# Altium schematic sheet lpGBT.SchDoc
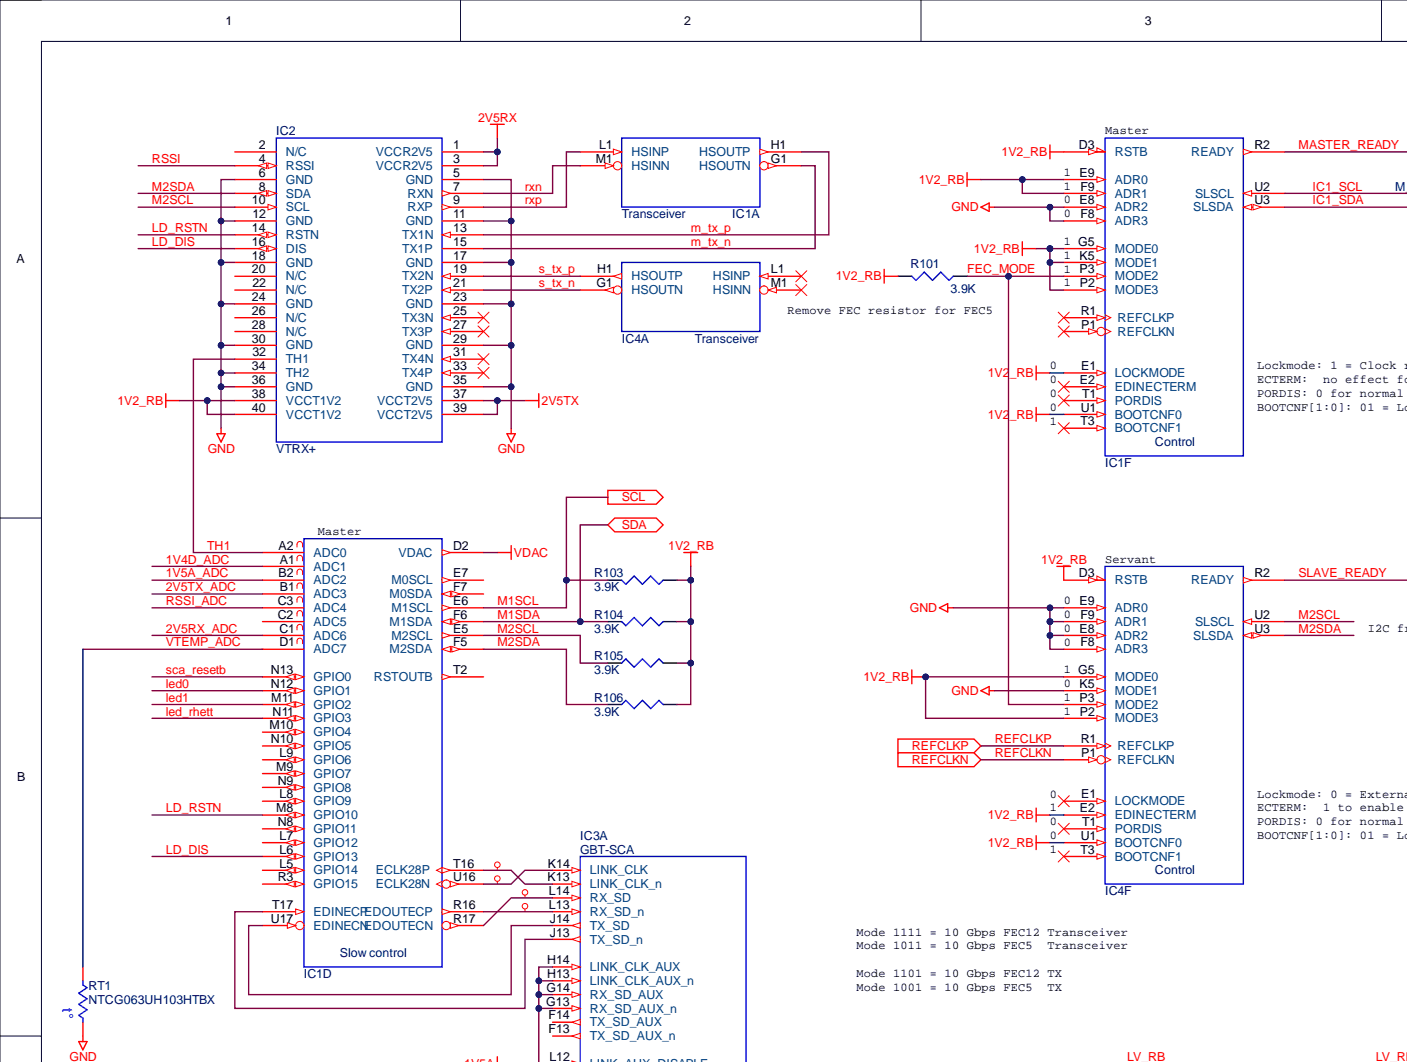
[diagram p0: lpGBT.SchDoc, top left]
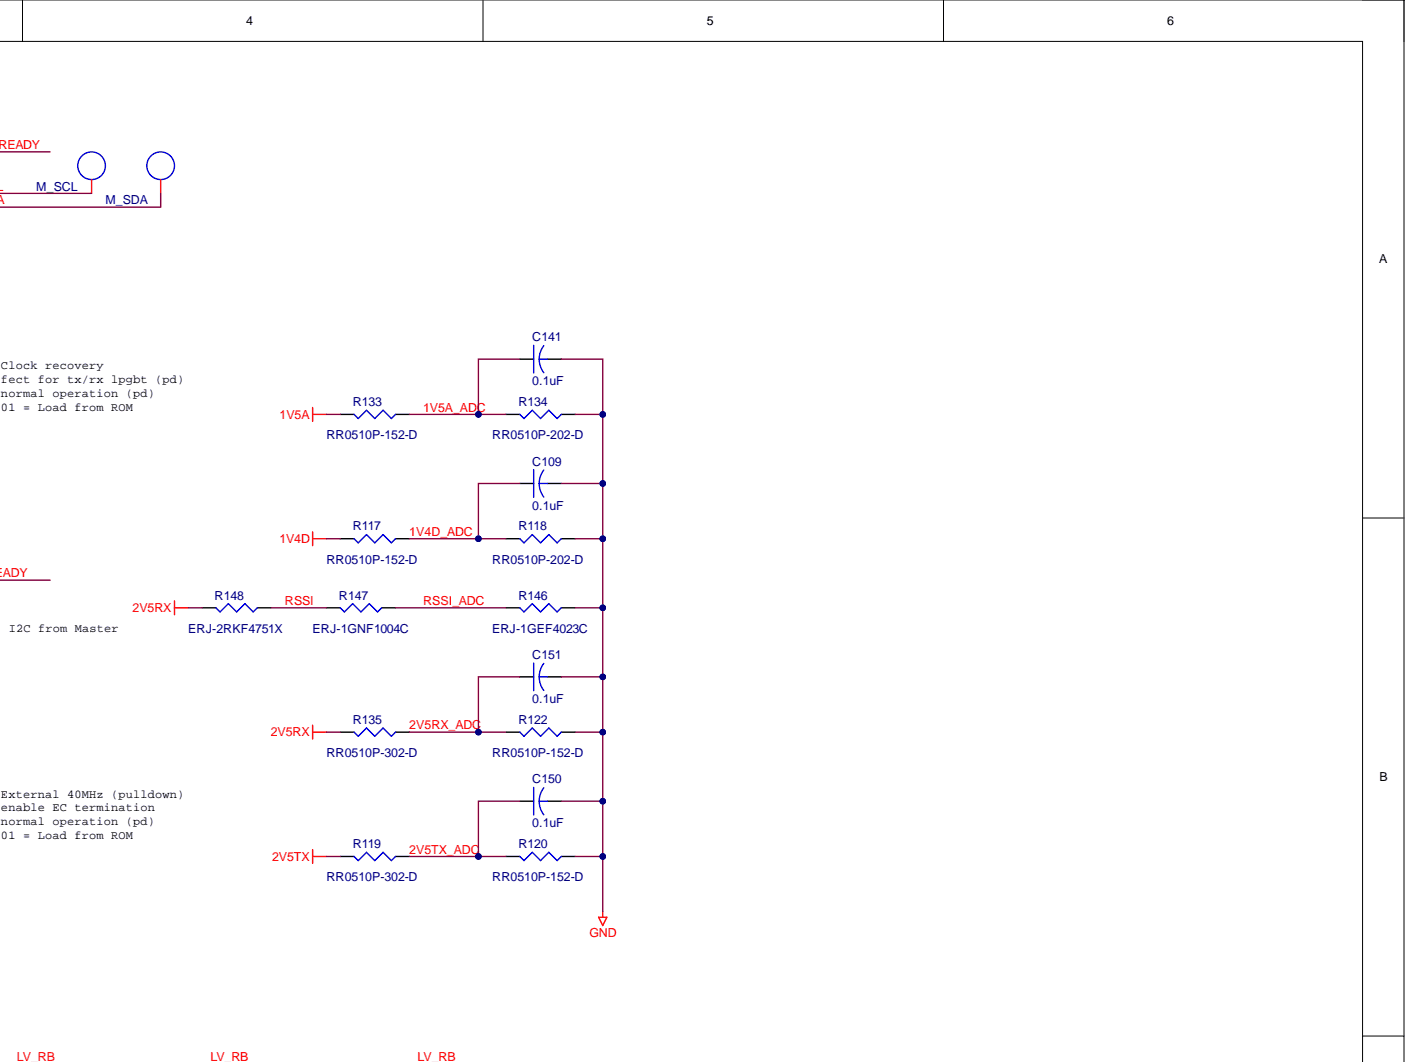
[diagram p1: lpGBT.SchDoc, top right]
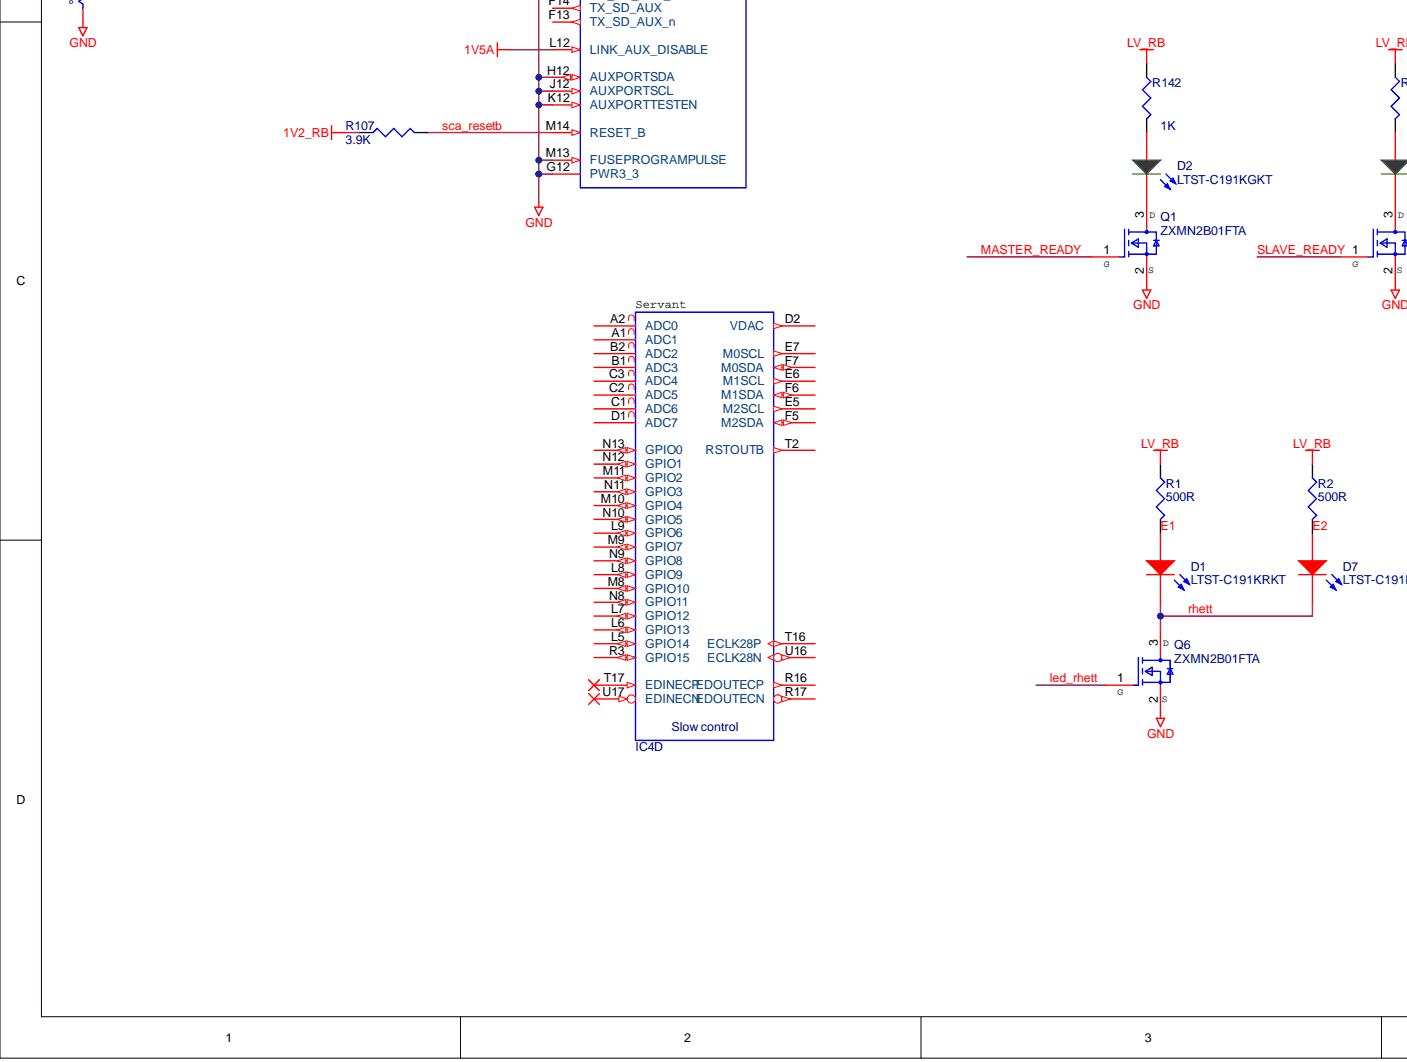
[diagram p2: lpGBT.SchDoc, bottom left]
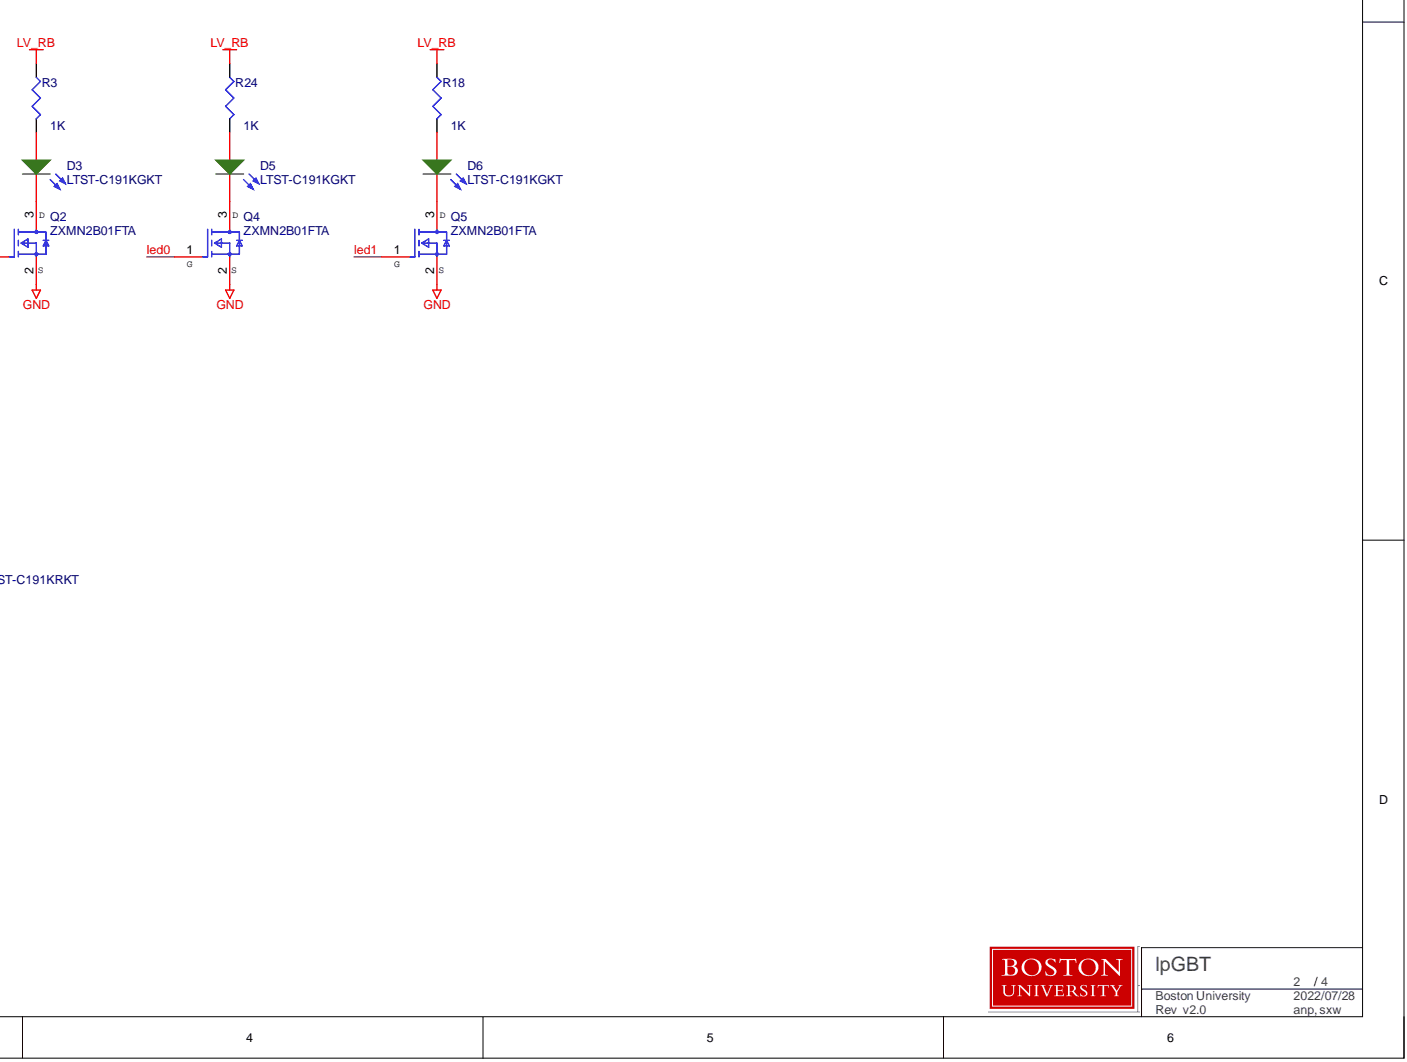
[diagram p3: lpGBT.SchDoc, bottom right]

## Parts
C109  value 0.1uF  [capc1005n]  (hidden comment: GRM155R71E104ME14D)
C141  value 0.1uF  [capc1005n]  (hidden comment: GRM155R71E104ME14D)
C150  value 0.1uF  [capc1005n]  (hidden comment: GRM155R71E104ME14D)
C151  value 0.1uF  [capc1005n]  (hidden comment: GRM155R71E104ME14D)
D1  LTST-C191KRKT  [LED_RED]
D2  LTST-C191KGKT  [LED_RED]
D3  LTST-C191KGKT  [LED_RED]
D5  LTST-C191KGKT  [LED_RED]
D6  LTST-C191KGKT  [LED_RED]
D7  LTST-C191KRKT  [LED_RED]
IC1  LPGBT  [BGA289C50P17X17_900X900X128]  (value not drawn)  (parts A,D,F)
IC2  DF40C-40DS-0.4V  [vtrx+]  (value not drawn)
IC3  GBT-SCA  [BGA196C80P14X14_1200X1200X170]  (part A)
IC4  LPGBT  [BGA289C50P17X17_900X900X128]  (value not drawn)  (parts A,D,F)
Q1  ZXMN2B01FTA  [SOT-23]
Q2  ZXMN2B01FTA  [SOT-23]
Q4  ZXMN2B01FTA  [SOT-23]
Q5  ZXMN2B01FTA  [SOT-23]
Q6  ZXMN2B01FTA  [SOT-23]
R1  Parameter 1: 500R  [resc1005n]  (hidden comment: ERJ-2GEJ102X)
R2  Parameter 1: 500R  [resc1005n]  (hidden comment: ERJ-2GEJ102X)
R3  ERJ-2GEJ102X  [resc1005n]  (value not drawn)
R18  ERJ-2GEJ102X  [resc1005n]  (value not drawn)
R24  ERJ-2GEJ102X  [resc1005n]  (value not drawn)
R101  Parameter 1: 3.9K  [resc1005n]  (hidden comment: ERJ-2GEJ392X)
R103  Parameter 1: 3.9K  [resc1005n]  (hidden comment: ERJ-2GEJ392X)
R104  Parameter 1: 3.9K  [resc1005n]  (hidden comment: ERJ-2GEJ392X)
R105  Parameter 1: 3.9K  [resc1005n]  (hidden comment: ERJ-2GEJ392X)
R106  Parameter 1: 3.9K  [resc1005n]  (hidden comment: ERJ-2GEJ392X)
R107  Parameter 1: 3.9K  [resc1005n]  (hidden comment: ERJ-2GEJ392X)
R117  RR0510P-152-D  [resc1005n]
R118  RR0510P-202-D  [resc1005n]
R119  RR0510P-302-D  [resc1005n]
R120  RR0510P-152-D  [resc1005n]
R122  RR0510P-152-D  [resc1005n]
R133  RR0510P-152-D  [resc1005n]
R134  RR0510P-202-D  [resc1005n]
R135  RR0510P-302-D  [resc1005n]
R142  ERJ-2GEJ102X  [resc1005n]  (value not drawn)
R146  ERJ-1GEF4023C  [resc0603]
R147  ERJ-1GNF1004C  [resc0603]
R148  ERJ-2RKF4751X  [resc1005n]
RT1  NTCG063UH103HTBX  [resc0603]
TP11  M_SCL  [testpoint]  (no BOM)
TP12  M_SDA  [testpoint]  (no BOM)

## Ports
REFCLKN, REFCLKP, SCL, SDA

## Nets
1V2_RB: IC1.D3(RSTB) IC1.E1(LOCKMODE) IC1.E9(ADR0) IC1.F9(ADR1) IC1.G5(MODE0) IC1.K5(MODE1) IC1.P2(MODE3) IC1.U1(BOOTCNF0) IC2.38(VCCT1V2) IC2.40(VCCT1V2) IC4.D3(RSTB) IC4.E2(EDINECTERM) IC4.G5(MODE0) IC4.P2(MODE3) IC4.U1(BOOTCNF0) R101.2 R103.1 R104.1 R105.2 R106.2 R107.1
1V4D: R117.2
1V5A: IC3.L12(LINK_AUX_DISABLE) R133.2
2V5RX: IC2.1(VCCR2V5) IC2.3(VCCR2V5) R135.2 R148.2
2V5TX: IC2.37(VCCT2V5) IC2.39(VCCT2V5) R119.2
GND: C109.2 C141.2 C150.2 C151.2 IC1.E8(ADR2) IC1.F8(ADR3) IC2.5(GND) IC2.6(GND) IC2.11(GND) IC2.12(GND) IC2.17(GND) IC2.18(GND) IC2.23(GND) IC2.24(GND) IC2.29(GND) IC2.30(GND) IC2.34(TH2) IC2.35(GND) IC2.36(GND) IC3.G12(PWR3_3) IC3.G13(RX_SD_AUX_n) IC3.G14(RX_SD_AUX) IC3.H12(AUXPORTSDA) IC3.H13(LINK_CLK_AUX_n) IC3.H14(LINK_CLK_AUX) IC3.J12(AUXPORTSCL) IC3.K12(AUXPORTTESTEN) IC3.M13(FUSEPROGRAMPULSE) IC4.E8(ADR2) IC4.E9(ADR0) IC4.F8(ADR3) IC4.F9(ADR1) IC4.K5(MODE1) Q1.2 Q2.2 Q4.2 Q5.2 Q6.2 R118.1 R120.1 R122.1 R134.1 R146.1 RT1.1
LV_RB: R1.1 R2.1 R3.1 R18.1 R24.1 R142.1
VDAC: IC1.D2(VDAC)
1V4D_ADC: C109.1 IC1.A1(ADC1) R117.1 R118.2
1V5A_ADC: C141.1 IC1.B2(ADC2) R133.1 R134.2
2V5RX_ADC: C151.1 IC1.C1(ADC6) R122.2 R135.1
2V5TX_ADC: C150.1 IC1.B1(ADC3) R119.1 R120.2
E1: D1.1 R1.2
E2: D7.1 R2.2
FEC_MODE: IC1.P3(MODE2) IC4.P3(MODE2) R101.1
IC1_SCL: IC1.U2(SLSCL) TP11.1
IC1_SDA: IC1.U3(SLSDA) TP12.1
LD_DIS: IC1.L6(GPIO13) IC2.16(DIS)
LD_RSTN: IC1.M8(GPIO10) IC2.14(RSTN)
led0: IC1.N12(GPIO1) Q4.1
led1: IC1.M11(GPIO2) Q5.1
led_rhett: IC1.N11(GPIO3) Q6.1
M1SCL: IC1.E6(M1SCL) R103.2 ; port SCL
M1SDA: IC1.F6(M1SDA) R104.2 ; port SDA
M2SCL: IC1.E5(M2SCL) IC2.10(SCL) IC4.U2(SLSCL) R105.1
M2SDA: IC1.F5(M2SDA) IC2.8(SDA) IC4.U3(SLSDA) R106.1
m_tx_n: IC1.G1(HSOUTN) IC2.15(TX1P)
m_tx_p: IC1.H1(HSOUTP) IC2.13(TX1N)
MASTER_READY: IC1.R2(READY) Q1.1
REFCLKN: IC4.P1(REFCLKN) ; port REFCLKN
REFCLKP: IC4.R1(REFCLKP) ; port REFCLKP
rhett: D1.2 D7.2 Q6.3
RSSI: IC2.4(RSSI) R147.2 R148.1
RSSI_ADC: IC1.C3(ADC4) R146.2 R147.1
rxn: IC1.M1(HSINN) IC2.7(RXN)
rxp: IC1.L1(HSINP) IC2.9(RXP)
s_tx_n: IC2.21(TX2P) IC4.G1(HSOUTN)
s_tx_p: IC2.19(TX2N) IC4.H1(HSOUTP)
sca_resetb: IC1.N13(GPIO0) IC3.M14(RESET_B) R107.2
SLAVE_READY: IC4.R2(READY) Q2.1
TH1: IC1.A2(ADC0) IC2.32(TH1)
VTEMP_ADC: IC1.D1(ADC7) RT1.2
NetD2_1: D2.1 R142.2
NetD2_2: D2.2 Q1.3
NetD3_1: D3.1 R3.2
NetD3_2: D3.2 Q2.3
NetD5_1: D5.1 R24.2
NetD5_2: D5.2 Q4.3
NetD6_1: D6.1 R18.2
NetD6_2: D6.2 Q5.3
NetIC1_R16: IC1.R16(EDOUTECP) IC3.L13(RX_SD_n)
NetIC1_R17: IC1.R17(EDOUTECN) IC3.L14(RX_SD)
NetIC1_T16: IC1.T16(ECLK28P) IC3.K13(LINK_CLK_n)
NetIC1_T17: IC1.T17(EDINECP) IC3.J13(TX_SD_n)
NetIC1_U16: IC1.U16(ECLK28N) IC3.K14(LINK_CLK)
NetIC1_U17: IC1.U17(EDINECN) IC3.J14(TX_SD)

Not connected: IC1 C2(ADC5) E2(EDINECTERM) E7(M0SCL) F7(M0SDA) L5(GPIO14) L7(GPIO12) L8(GPIO9) L9(GPIO6) M9(GPIO7) M10(GPIO4) N8(GPIO11) N9(GPIO8) N10(GPIO5) P1(REFCLKN) R1(REFCLKP) R3(GPIO15) T1(PORDIS) T2(RSTOUTB) T3(BOOTCNF1); IC2 2(N/C) 20(N/C) 22(N/C) 25(TX3N) 26(N/C) 27(TX3P) 28(N/C) 31(TX4N) 33(TX4P); IC3 F13(TX_SD_AUX_n) F14(TX_SD_AUX); IC4 A1(ADC1) A2(ADC0) B1(ADC3) B2(ADC2) C1(ADC6) C2(ADC5) C3(ADC4) D1(ADC7) D2(VDAC) E1(LOCKMODE) E5(M2SCL) E6(M1SCL) E7(M0SCL) F5(M2SDA) F6(M1SDA) F7(M0SDA) L1(HSINP) L5(GPIO14) L6(GPIO13) L7(GPIO12) L8(GPIO9) L9(GPIO6) M1(HSINN) M8(GPIO10) M9(GPIO7) M10(GPIO4) M11(GPIO2) N8(GPIO11) N9(GPIO8) N10(GPIO5) N11(GPIO3) N12(GPIO1) N13(GPIO0) R3(GPIO15) R16(EDOUTECP) R17(EDOUTECN) T1(PORDIS) T2(RSTOUTB) T3(BOOTCNF1) T16(ECLK28P) T17(EDINECP) U16(ECLK28N) U17(EDINECN)

## Library data of the parts
C109 C141 C150 C151 = C: CERAMIC CAPACITOR; Component Kind: Standard; Library Name: Schematic Component.SchLib
D1 D7 = LED_RED: Typical RED, GREEN, YELLOW, AMBER GaAs LED; Component Kind: Standard; LatestRevisionDate: 17-Jul-2002; LatestRevisionNote: Re-released for DXP Platform.; PackageDescription: SM LED; 2 Flat Leads; PackageReference: 3.2X1.6X1.1; PackageVersion: Feb-2002; Published: 8-Jun-2000; Simulation: LED2; Library Name: ETL_RB.SCHLIB; Publisher: Altium Limited
D2 D3 D5 D6 = LED_GREEN: Typical RED, GREEN, YELLOW, AMBER GaAs LED; Component Kind: Standard; LatestRevisionDate: 17-Jul-2002; LatestRevisionNote: Re-released for DXP Platform.; PackageDescription: SM LED; 2 Flat Leads; PackageReference: 3.2X1.6X1.1; PackageVersion: Feb-2002; Published: 8-Jun-2000; Simulation: LED2; Library Name: ETL_RB.SCHLIB; Publisher: Altium Limited
IC1 IC4 = LPGBT: Low Power Gigabit Transceiver; Manufacturer: CERN; Bonding: No; Pinout Version: 180226; Case: BGA289; Component Kind: Standard; Component Type: Standard; Device: LPGBT; LatestRevisionDate: 26.10.2018; Manufacturer Part Number: LPGBT; Mounted: Yes; PackageDescription: BGA, 289-Leads, Body 9.00x9.00mmx1.28mm, Pitch 0.50mm; Part Description: Low Power Gigabit Transceiver; Part Number: LPGBT; Pin Count: 289; SMD: Yes; Socket: No; Library Name: ETL_RB.SCHLIB
IC2 = VTRX+_DF40C-2.0-40DS-0.4V: VTRX+ module with 2.5mm stack Height.; Component Kind: Standard; Parameter 1: VTRX+; Library Name: ETL_RB.SCHLIB
IC3 = CERN_GBT-SCA_REV 6.2: The Slow Control Adapter ASIC for the GBT System; Component Kind: Standard; Device: GBT-SCA; Library Name: ETL_RB.SCHLIB
Q1 Q2 Q4-Q6 = ZXMN2B01FTA: MOSFET N-CH 20V 2.1A SOT-23-3; Component Kind: Standard; ComponentLink2Description: Datasheet; ComponentLink2URL: https://www.diodes.com/assets/Datasheets/ZXMN2B01F.pdf; Library Name: ETL_RB.SCHLIB
R1 R2 R101 R103 R104 R107 = R1: FILM RESISTOR; Text Field2: 5%; Component Kind: Standard; Library Name: mysch.SCHLIB
R3 R18 R24 R142 = R1: FILM RESISTOR; Parameter 1: 1K; Text Field2: 5%; Component Kind: Standard; Library Name: mysch.SCHLIB
R105 R106 R117-R120 R122 R133-R135 = R1: FILM RESISTOR; Text Field2: 1%; Component Kind: Standard; Library Name: mysch.SCHLIB
R146 = R1: FILM RESISTOR; Parameter 1: 402K; Text Field2: 1%; Component Kind: Standard; Library Name: mysch.SCHLIB
R147 = R1: FILM RESISTOR; Parameter 1: 1M; Text Field2: 1%; Component Kind: Standard; Library Name: mysch.SCHLIB
R148 = R1: FILM RESISTOR; Parameter 1: 4.75K; Text Field2: 1%; Component Kind: Standard; Library Name: mysch.SCHLIB
RT1 = Res Thermal: Thermistor; Code_IPC: RESC2012; Component Kind: Standard; LatestRevisionDate: 29-May-2009; LatestRevisionNote: IPC-7351 Footprint Added.; PackageDescription: Chip Resistor 0805 Case Size; PackageReference: 6-0805; PackageVersion: Mar-2003; Published: 24-Mar-1999; Library Name: ETL_RB.SCHLIB; Publisher: Altium Limited
TP11 TP12 = TP: TEST POINT 40MIL DIA.; Library Name: ETL_RB.SCHLIB
